# Altium schematic sheet MCU.SchDoc(MCU)
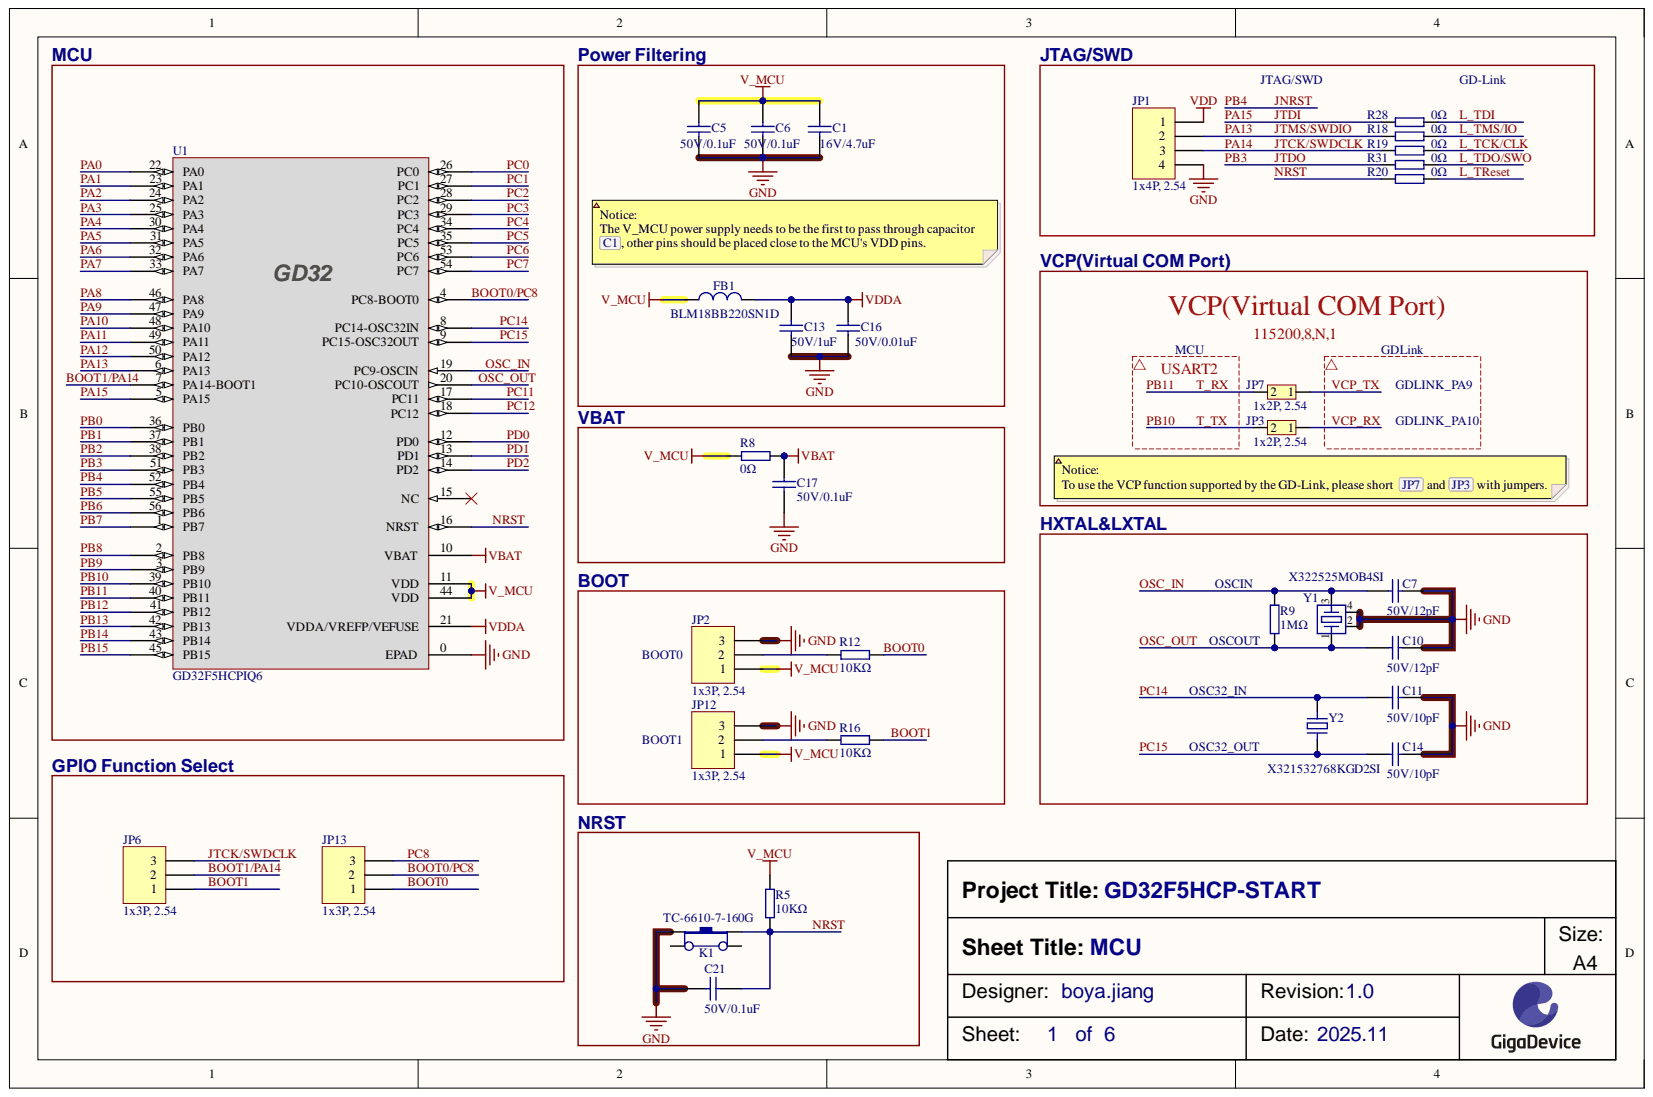
[diagram p0: MCU.SchDoc]

## Parts
C1  16V/4.7uF  [0603-C]
C5  50V/0.1uF  [0402-C]
C6  50V/0.1uF  [0402-C]
C7  50V/12pF  [0603-C]
C10  50V/12pF  [0603-C]
C11  50V/10pF  [0603-C]
C13  50V/1uF  [0402-C]
C14  50V/10pF  [0603-C]
C16  50V/0.01uF  [0402-C]
C17  50V/0.1uF  [0402-C]
C21  50V/0.1uF  [0603-C]
FB1  BLM18BB220SN1D  [0603-R]
JP1  1x4P, 2.54  [SIP-4]
JP2  1x3P, 2.54  [SIP-3]
JP3  1x2P, 2.54  [SIP-2]
JP6  1x3P, 2.54  [SIP-3]
JP7  1x2P, 2.54  [SIP-2]
JP12  1x3P, 2.54  [SIP-3]
JP13  1x3P, 2.54  [SIP-3]
K1  TC-6610-7-160G  [K-1102B]
R5  10KΩ  [0603-R]
R8  0Ω  [0402-R]
R9  1MΩ  [0603-R]
R12  10KΩ  [0603-R]
R16  10KΩ  [0603-R]
R18  0Ω  [0603-R]
R19  0Ω  [0603-R]
R20  0Ω  [0603-R]
R28  0Ω  [0603-R]
R31  0Ω  [0603-R]
U1  GD32F5HCPIQ6  [QFN56_7X7X0.8]
Y1  X322525MOB4SI  [SMD3225-4P]
Y2  X321532768KGD2SI  [3215]

## Nets
GND: C1.1 C5.1 C6.1 C7.1 C10.1 C11.2 C13.2 C14.1 C16.2 C17.2 C21.2 JP1.4 JP2.3 JP12.3 K1.1 U1.0(EPAD) Y1.2 Y1.4
V_MCU: C1.2 C5.2 C6.2 FB1.1 JP2.1 JP12.1 R5.2 R8.2 U1.11(VDD) U1.44(VDD)
VBAT: C17.1 R8.1 U1.10(VBAT)
VDD: JP1.1
VDDA: C13.1 C16.1 FB1.2 U1.21(VDDA/VREFP/VEFUSE)
BOOT0: JP13.1 R12.1
BOOT0/PC8: JP13.2 U1.4(PC8-BOOT0)
BOOT1: JP6.1 R16.1
BOOT1/PA14: JP6.2 U1.7(PA14-BOOT1)
JNRST: U1.52(PB4)
JTCK/SWDCLK: JP1.3 JP6.3 R19.2
JTDI: R28.2 U1.5(PA15)
JTDO: R31.2 U1.51(PB3)
JTMS/SWDIO: JP1.2 R18.2 U1.6(PA13)
L_TCK/CLK: R19.1
L_TDI: R28.1
L_TDO/SWO: R31.1
L_TMS/IO: R18.1
L_TReset: R20.1
NRST: C21.1 K1.3 R5.1 R20.2 U1.16(NRST)
OSC_IN: C7.2 R9.2 U1.19(PC9-OSCIN) Y1.3
OSC_OUT: C10.2 R9.1 U1.20(PC10-OSCOUT) Y1.1
PA0: U1.22(PA0)
PA1: U1.23(PA1)
PA2: U1.24(PA2)
PA3: U1.25(PA3)
PA4: U1.30(PA4)
PA5: U1.31(PA5)
PA6: U1.32(PA6)
PA7: U1.33(PA7)
PA8: U1.46(PA8)
PA9: U1.47(PA9)
PA10: U1.48(PA10)
PA11: U1.49(PA11)
PA12: U1.50(PA12)
PB0: U1.36(PB0)
PB1: U1.37(PB1)
PB2: U1.38(PB2)
PB5: U1.55(PB5)
PB6: U1.56(PB6)
PB7: U1.1(PB7)
PB8: U1.2(PB8)
PB9: U1.3(PB9)
PB10: JP3.2 U1.39(PB10)
PB11: JP7.2 U1.40(PB11)
PB12: U1.41(PB12)
PB13: U1.42(PB13)
PB14: U1.43(PB14)
PB15: U1.45(PB15)
PC0: U1.26(PC0)
PC1: U1.27(PC1)
PC2: U1.28(PC2)
PC3: U1.29(PC3)
PC4: U1.34(PC4)
PC5: U1.35(PC5)
PC6: U1.53(PC6)
PC7: U1.54(PC7)
PC8: JP13.3
PC11: U1.17(PC11)
PC12: U1.18(PC12)
PC14: C11.1 U1.8(PC14-OSC32IN) Y2.2
PC15: C14.2 U1.9(PC15-OSC32OUT) Y2.1
PD0: U1.12(PD0)
PD1: U1.13(PD1)
PD2: U1.14(PD2)
VCP_RX: JP3.1
VCP_TX: JP7.1
NetJP2_2: JP2.2 R12.2
NetJP12_2: JP12.2 R16.2

Not connected: K1 2 4; U1 15(NC)

## Library data of the parts
C1 = 50V/0.1uF: 表贴电容, X7R; Component Kind: Standard; Library Name: KLTC-电容.SchLib
C5 C6 C13 C16 C17 C21 = 50V/0.1uF: 表贴电容; Component Kind: Standard; Library Name: KLTC-电容.SchLib
C7 C10 = 50V/20pF: 表贴电容; Component Kind: Standard; Library Name: KLTC-电容.SchLib
C11 C14 = 50V/10pF: 表贴电容; Component Kind: Standard; Library Name: KLTC-电容.SchLib
FB1 = BLM21AG221SN1D: 表贴磁珠, 22Ω@100MHz, DCR:200mΩ, 700mA; Component Kind: Standard; Library Name: KLTC-电感及磁珠.SchLib
JP1 = HEADER 4: 单排插针; Note: 不焊; Component Kind: Standard; Library Name: KLTC-按键及接插件.SchLib
JP2 JP6 JP12 JP13 = HEADER 3: 单排插针; Component Kind: Standard; Library Name: KLTC-按键及接插件.SchLib
JP3 JP7 = HEADER 1x2: 单排插针; Component Kind: Standard; Library Name: boyajiang.SchLib
K1 = K-1102B: 直插6*6*7mm轻触按键开关, 单刀单掷, 1.6N; Component Kind: Standard; Library Name: KLTC-按键及接插件.SchLib
R5 R12 R16 = 10KΩ: 表贴电阻; Component Kind: Standard; Library Name: KLTC-电阻.SchLib
R8 R9 = 1MΩ: 表贴电阻; Component Kind: Standard; Library Name: KLTC-电阻.SchLib
R18-R20 R28 R31 = 1.5KΩ: 表贴电阻; Component Kind: Standard; Library Name: KLTC-电阻.SchLib
U1 = GD32F5HCPxQx: 表贴MCU; Database Table Name: GD-MCU; Note: GD供料; Component Kind: Standard; ID: GD32F5HCPxQx; Library Name: SVNDatabase.SVNDbLib
Y1 = X322525MOB4SI: 表贴无源晶振, SMD3225-4P, 12pF; Manufacturer: JWT(晶威特); Add into BOM: yes; Device: CF4025M00012; Frequency Stability: ±20ppm; Frequency Tolerance: ±10ppm; Name: 25MHz; Value: 25MHz; Component Kind: Standard; Convert to PCB: yes; Datasheet: https://atta.szlcsc.com/upload/public/pdf/source/20230519/8BD53FE1B1EDD8711DA53080BED03017.pdf; LCSC Part Name: 25MHz ±10ppm 12pF; Load Capacitance: 12pF; Manufacturer Part: CF4025M00012; PCB3D: CRYSTAL-SMD_4P-L3.2-W2.5-BL_SIT8008BI; Supplier: LCSC; Supplier Footprint: SMD3225-4P; Supplier Part: C5765971; Symbol: CF4025M00012; Library Name: boyajiang.SchLib
Y2 = X321532768KGD2SI: 表贴32.768KHz无源晶振, SMD-3215-2P, 12.5pF; Manufacturer: JWT(晶威特); Add into BOM: yes; Device: CF4025M00012; Frequency Stability: ±20ppm; Frequency Tolerance: ±10ppm; Load Capacitance: 12pF; Name: 25MHz; Value: 25MHz; Component Kind: Standard; Convert to PCB: yes; Datasheet: https://atta.szlcsc.com/upload/public/pdf/source/20230519/8BD53FE1B1EDD8711DA53080BED03017.pdf; LCSC Part Name: 25MHz ±10ppm 12pF; Manufacturer Part: CF4025M00012; PCB3D: CRYSTAL-SMD_4P-L3.2-W2.5-BL_SIT8008BI; Supplier: LCSC; Supplier Footprint: SMD3225-4P; Supplier Part: C5765971; Symbol: CF4025M00012; Library Name: boyajiang.SchLib
